# Altium schematic sheet PSBoard.SchDoc
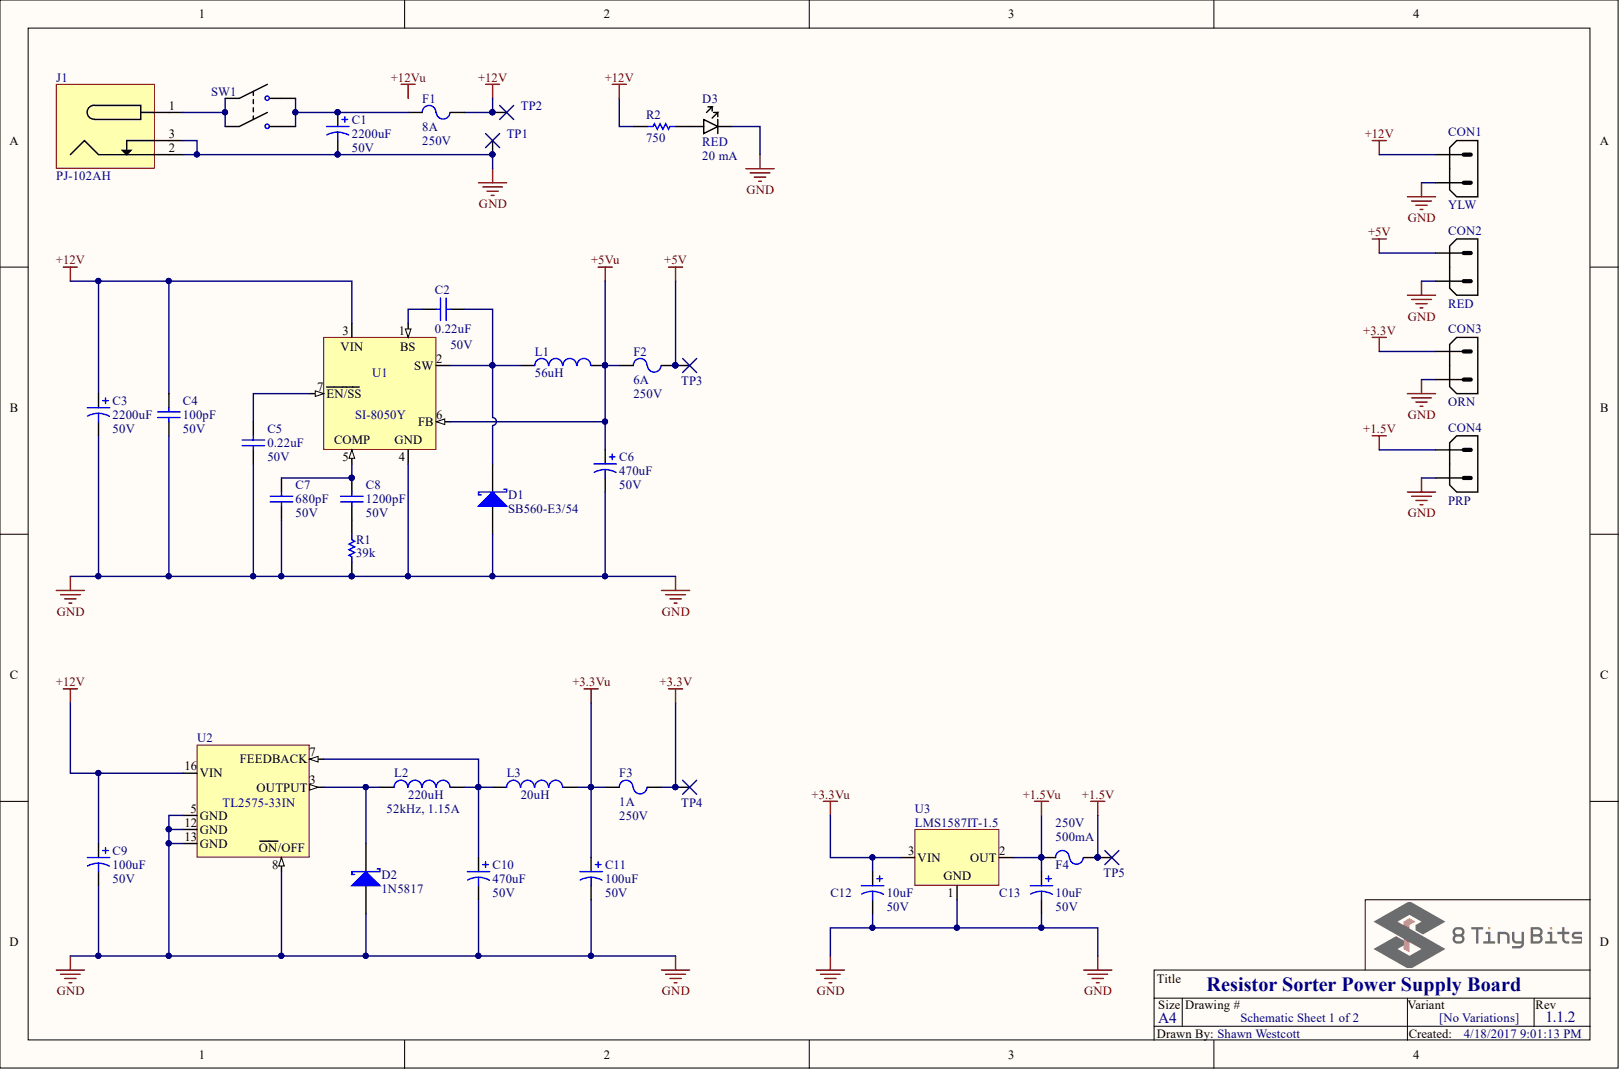
[diagram p0: PSBoard.SchDoc]

## Parts
C1  value 2200uF  Vmax: 50V  [50PX2200MEFC16X31.5]  (hidden comment: Cap Pol1)
C2  value 0.22uF  [JKE, 0.22uF]  (hidden comment: Cap)
C3  value 2200uF  Vmax: 50V  [50PX2200MEFC16X31.5]  (hidden comment: Cap Pol1)
C4  value 100pF  Vmax: 50V  [JKE, 100pF]  (hidden comment: Cap)
C5  value 0.22uF  Vmax: 50V  [JKE, 0.22uF]  (hidden comment: Cap)
C6  value 470uF  Vmax: 50V  [JKE, 470uF]  (hidden comment: Cap Pol1)
C7  value 680pF  Vmax: 50V  [JKE, 680pF]  (hidden comment: Cap)
C8  value 1200pF  Vmax: 50V  [Cap, Cer, 0.157" L x 0.098" W]  (hidden comment: Cap)
C9  value 100uF  Vmax: 50V  [Cap, Alum, 150mil Radial, Polarized]  (hidden comment: Cap Pol1)
C10  value 470uF  Vmax: 50V  [JKE, 470uF]  (hidden comment: Cap Pol1)
C11  value 100uF  Vmax: 50V  [Cap, Alum, 150mil Radial, Polarized]  (hidden comment: Cap Pol1)
C12  value 10uF  Vmax: 50V  [JKE, 10uF]  (hidden comment: Cap Pol1)
C13  value 10uF  Vmax: 50V  [JKE, 10uF]  (hidden comment: Cap Pol1)
CON1  Color: YLW  [CP-6.5_2PIN]  (hidden comment: CP-6.5 Connectors)
CON2  Color: RED  [CP-6.5_2PIN]  (hidden comment: CP-6.5 Connectors)
CON3  Color: ORN  [CP-6.5_2PIN]  (hidden comment: CP-6.5 Connectors)
CON4  Color: PRP  [CP-6.5_2PIN]  (hidden comment: CP-6.5 Connectors)
D1  SB560-E3/54  [DO201AD]
D2  1N5817  [DO-204AL, DO-41, Axial]
D3  Color: RED  Current: 20 mA  [5mm_THRU_LED]  (hidden comment: Generic LED)
F1  8A  Max Voltage: 250V  [0031.8211]
F2  6A  Max Voltage: 250V  [0031.8211]
F3  1A  Max Voltage: 250V  [0031.8211]
F4  500mA  Max Voltage: 250V  [0031.8211]
J1  PJ-102AH  [PJ-102AH]
L1  value 56uH  [2310-V-RC]  (hidden comment: Inductor)
L2  value 220uH  Notes: 52kHz, 1.15A  [732-4195-ND]  (hidden comment: Inductor)
L3  value 20uH  [IND-8095]  (hidden comment: Inductor)
R1  value 39k  [Axial, 0.6 (1/4W Std)]  (hidden comment: Res1)
R2  value 750  [Axial, 0.6 (1/4W Std)]  (hidden comment: Res1)
SW1  CWI494-ND  [DPST_WIRECONN_15AWG]  (value not drawn)
TP1  TEST POINT  [TP_Hook]  (value not drawn)
TP2  TEST POINT  [TP_PLATING]  (value not drawn)
TP3  TEST POINT  [TP_PLATING]  (value not drawn)
TP4  TEST POINT  [TP_PLATING]  (value not drawn)
TP5  TEST POINT  [TP_PLATING]  (value not drawn)
U1  SI-8050Y  [TO-220-7 (Formed Leads)]
U2  TL2575-33IN  [DIP-16]
U3  LMS1587IT-1.5  [TO-220-3]

## Nets
+1.5V: CON4.2 F4.1 TP5.0
+1.5VU: C13.1 F4.2 U3.2
+3.3V: CON3.2 F3.2 TP4.0
+3.3VU: C11.1 C12.1 F3.1 L3.2 U3.3
+5V: CON2.2 F2.2 TP3.0
+5VU: C6.1 F2.1 L1.2 U1.6(FB)
+12V: C3.1 C4.2 C9.1 CON1.2 F1.2 R2.2 TP2.0 U1.3 U2.16(VIN)
GND: C1.2 C3.2 C4.1 C5.1 C6.2 C7.1 C9.2 C10.2 C11.2 C12.2 C13.2 CON1.1 CON2.1 CON3.1 CON4.1 D1.3 D2.3 D3.K J1.2 J1.3 R1.1 TP1.0 U1.4 U2.5(GND) U2.8 U2.12(GND) U2.13(GND) U3.1
NetC1_1: C1.1 F1.1 SW1.2 SW1.4
NetC2_1: C2.1 D1.1 L1.1 U1.2(SW)
NetC2_2: C2.2 U1.1(BS)
NetC5_2: C5.2 U1.7(EN/SS)
NetC7_2: C7.2 C8.2 U1.5
NetC8_1: C8.1 R1.2
NetC10_1: C10.1 L2.2 L3.1 U2.7(FEEDBACK)
NetD2_1: D2.1 L2.1 U2.3(OUTPUT)
NetD3_A: D3.A R2.1
NetJ1_1: J1.1 SW1.1 SW1.3
+12VU:

## Library data of the parts
C1 C3 C6 C9-C13 = Cap Pol1: Polarized Capacitor (Radial); Component Kind: Standard; LatestRevisionDate: 17-Jul-2002; LatestRevisionNote: Re-released for DXP Platform.; Library Name: Miscellaneous Devices.IntLib; PackageDescription: Polarized Capacitor (Radial); 2 Leads; PackageReference: RB7.6-15; Published: 8-Jun-2000; Publisher: Altium Limited
C2 = Cap: Capacitor; Vmax: 50V; Component Kind: Standard; LatestRevisionDate: 17-Jul-2002; LatestRevisionNote: Re-released for DXP Platform.; Library Name: Miscellaneous Devices.IntLib; PackageDescription: Radial Cap, Thru-Hole; 2 Leads; 0.3 in Pin Spacing; PackageReference: RAD-0.3; Published: 8-Jun-2000; Publisher: Altium Limited
C4 C5 C7 C8 = Cap: Capacitor; Component Kind: Standard; LatestRevisionDate: 17-Jul-2002; LatestRevisionNote: Re-released for DXP Platform.; Library Name: Miscellaneous Devices.IntLib; PackageDescription: Radial Cap, Thru-Hole; 2 Leads; 0.3 in Pin Spacing; PackageReference: RAD-0.3; Published: 8-Jun-2000; Publisher: Altium Limited
CON1-CON4 = CP-6.5 Connectors: 2 Positions Header, Shrouded Connector 0.256" (6.50mm) Through Hole Tin; Component Kind: Standard; Library Name: Custom_8TB.SchLib
D1 D2 = Diode 10TQ035: Schottky Rectifier; Note: Terminal 2 is the TAB and is electrically connected to the cathode; Component Kind: Standard; ComponentLink1Description: Manufacturer Link; ComponentLink1URL: http://www.irf.com/; ComponentLink2Description: Datasheet; ComponentLink2URL: http://www.irf.com/product-info/datasheets/data/10tq.pdf; DatasheetVersion: PD-2.057B; LatestRevisionDate: 17-Jul-2002; LatestRevisionNote: Re-released for DXP Platform.; Library Name: Miscellaneous Devices.IntLib; PackageDescription: TO, Thru-Hole, Vertical, Heatsink Mounted; 3 In-Line Leads (1 Absent); Pitch 2.54 mm; PackageReference: TO-220AC; PackageVersion: Feb-1998; Published: 8-Jun-2000; Publisher: Altium Limited
D3 = LED: Component Kind: Standard; Description: Generic LED; Library Name: Custom_8TB.SchLib
F1-F4 = Fuse 5mm x 20mm: Fuse Block 10A 500V 1 Circuit Cartridge; Mfr1: Schurter Inc.; Component Kind: Standard; Library Name: Custom_8TB.SchLib; Mfr1 PN: 0031.8211
  F1: Break Current: 8A
  F2: Break Current: 6A
  F3: Break Current: 1A
  F4: Break Current: 500mA
J1 = PJ-102AH: Power Barrel Connector Jack 2.10mm ID (0.083"), 5.50mm OD (0.217") Through Hole, Right Angle; Mfr1: CUI Inc; Component Kind: Standard; Library Name: Custom_8TB.SchLib; Mfr1 PN: PJ-102AH
L1-L3 = Inductor: Code_IEC: 1005; Code_JEITA: 0402; Component Kind: Standard; Description: Inductor; LatestRevisionDate: 29-May-2009; LatestRevisionNote: IPC-7351 Footprint Added.; Library Name: Miscellaneous Devices.IntLib; PackageDescription: Chip Inductor; PackageReference: 0402-A; Published: 8-Jun-2000; Publisher: Altium Limited
R1 R2 = Res1: Resistor; Component Kind: Standard; LatestRevisionDate: 17-Jul-2002; LatestRevisionNote: Re-released for DXP Platform.; Library Name: Miscellaneous Devices.IntLib; PackageDescription: Axial Device, Thru-Hole; 2 Leads; 0.3 in Pin Spacing; PackageReference: AXIAL-0.3; Published: 8-Jun-2000; Publisher: Altium Limited
  R2: Signal Integrity: Res; Simulation: RESISTOR
SW1 = DPST_SWITCH: Rocker Switch DPST 16A (AC), 10A (DC) 125V Panel Mount, Snap-In; Component Kind: Standard; Library Name: Custom_8TB.SchLib
TP1-TP5 = TP: GEN TEST POINT; Component Kind: Standard; Library Name: Custom_8TB.SchLib
U1 = SI-8050Y: Buck Switching Regulator IC Positive Fixed 5V 1 Output 8A TO-220-7 (Formed Leads); Mfr1: Sanken; Component Kind: Standard; Library Name: Custom_8TB.SchLib; Mfr1 PN: SI-8050Y
U2 = TL2575-33IN: Buck Switching Regulator IC Positive Fixed 3.3V 1 Output 1A 16-DIP (0.300", 7.62mm); Mfr1: TI; Component Kind: Standard; Library Name: Custom_8TB.SchLib; Mfr1 PN: TL2575-33IN
U3 = LMS1587IT-1.5: Linear Voltage Regulator IC Positive Fixed 1 Output 1.5V 3A TO-220-3; Mfr1: TI; Mfr1 PN: LMS1587IT-1.5/NOPB; Component Kind: Standard; Library Name: Custom_8TB.SchLib
